# Altium schematic sheet connectors.SchDoc
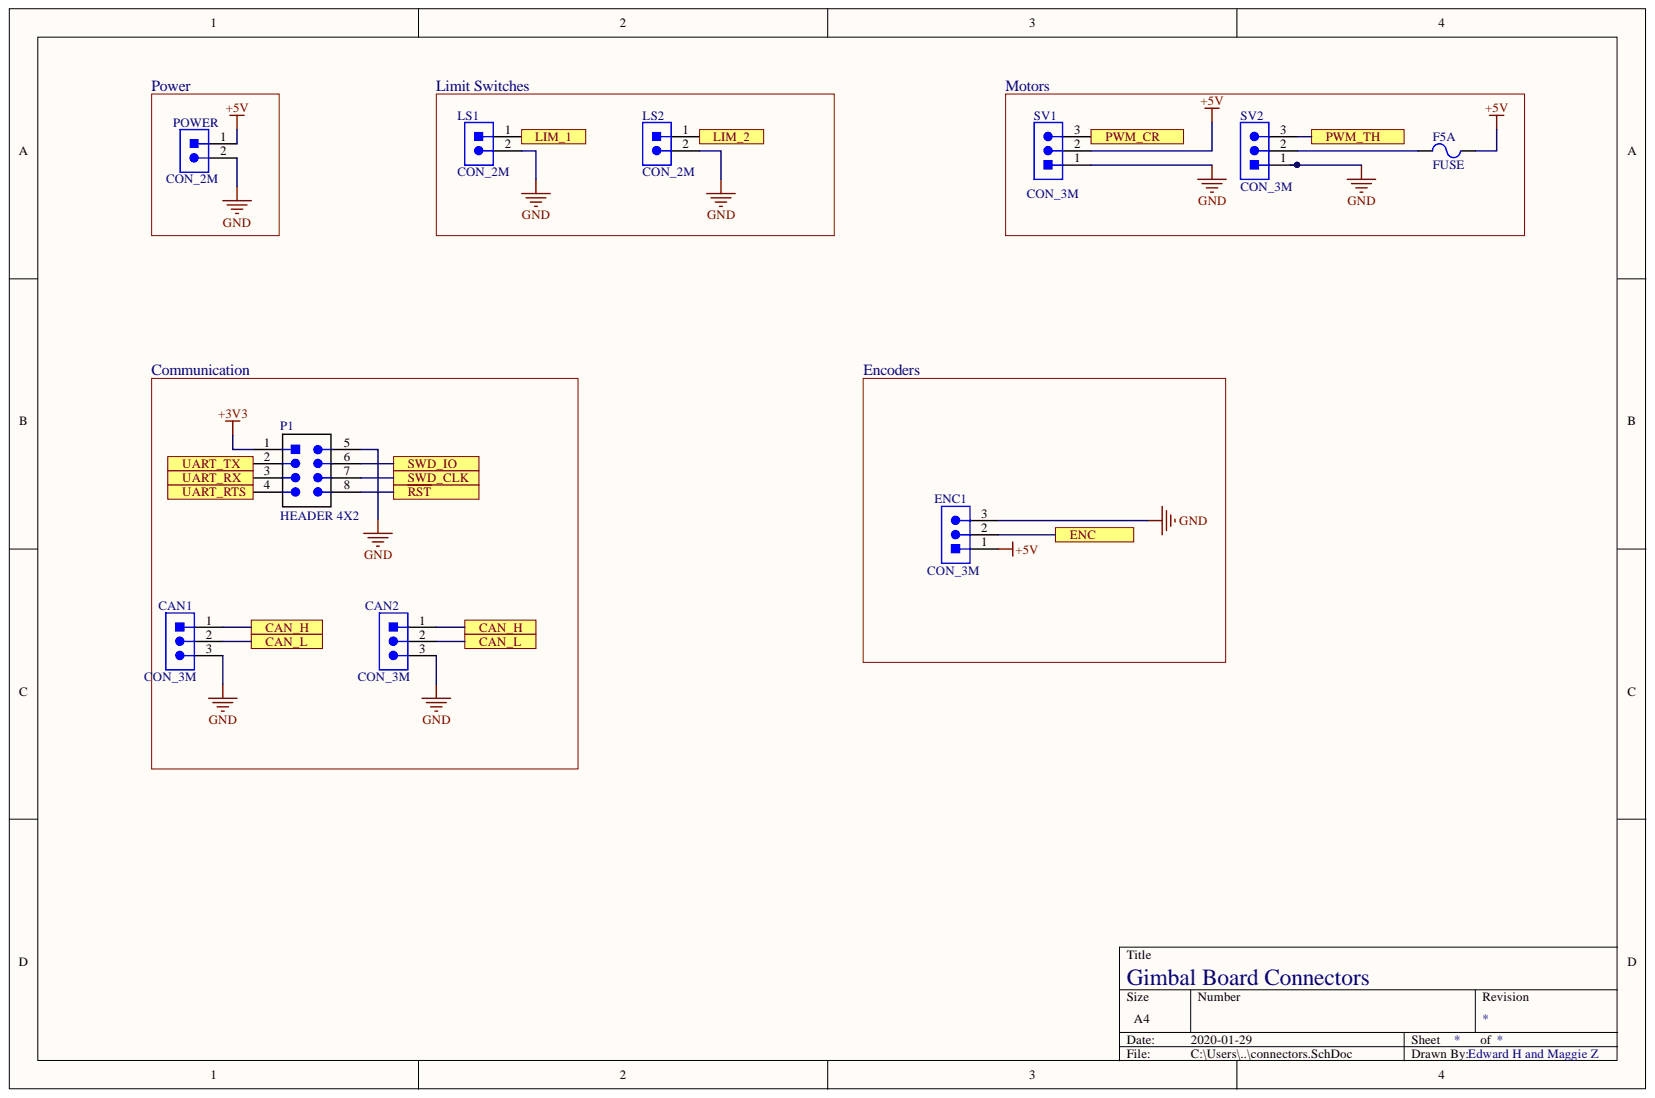
[diagram p0: connectors.SchDoc]

## Parts
CAN1  CON_3M  [JST-B3B-SM4-TB]
CAN2  CON_3M  [JST-B3B-SM4-TB]
ENC1  CON_3M  [JST-B3B-SM4-TB]
F5A  FUSE  [FUSE]
LS1  CON_2M  [JST-B2B-SM4-TB]
LS2  CON_2M  [JST-B2B-SM4-TB]
P1  HEADER 4X2  [HEADER_2X4]
POWER  CON_2M  [JST-B2B-PHSK]
SV1  CON_3M  [JST-B3B-SM4-TB]
SV2  CON_3M  [JST B03B-PASK]

## Ports
CAN_H, CAN_L, ENC, LIM_1, LIM_2, PWM_CR, PWM_TH, SWD_CLK, SWD_IO, UART_RTS, UART_RX, UART_TX, ~{RST}

## Nets
+3V3: P1.1
+5V: ENC1.1 F5A.2 POWER.1 SV1.2
GND: CAN1.3 CAN2.3 ENC1.3 LS1.2 LS2.2 P1.5 POWER.2 SV1.1 SV2.1
~{RST}: P1.8 ; port ~{RST}
CAN_H: CAN1.1 CAN2.1 ; port CAN_H
CAN_L: CAN1.2 CAN2.2 ; port CAN_L
ENC: ENC1.2 ; port ENC
LIM_1: LS1.1 ; port LIM_1
LIM_2: LS2.1 ; port LIM_2
PWM_CR: SV1.3 ; port PWM_CR
PWM_TH: SV2.3 ; port PWM_TH
SWD_CLK: P1.7 ; port SWD_CLK
SWD_IO: P1.6 ; port SWD_IO
UART_RTS: P1.4 ; port UART_RTS
UART_RX: P1.3 ; port UART_RX
UART_TX: P1.2 ; port UART_TX
NetF5A_1: F5A.1 SV2.2

## Library data of the parts
F5A = FUSE-5A: FUSE HOLDER RADIAL 250V 6.3A PCB; Component Kind: Standard; Library Name: UWRT_Schematic_Library_2019.SchLib
P1 = HEADER 4X2: Header, 4-Pin Dual row; Manufacturer: Sullins Connector Solutions; MPN: GRPB042VWVN-RC; Component Kind: Standard; Digikey Part Number: S9015E-04-ND; Signal Integrity: Connector; Library Name: UWRT_Schematic_Library_2019.SchLib
CAN1 CAN2 ENC1 SV1 SV2 = CON_3M: Component Kind: Standard; Library Name: UWRT_Schematic_Library_2019.SchLib
LS1 LS2 POWER = CON_2M: Component Kind: Standard; Library Name: UWRT_Schematic_Library_2019.SchLib
